# Altium schematic sheet AnalogSensorBoard.SchDoc
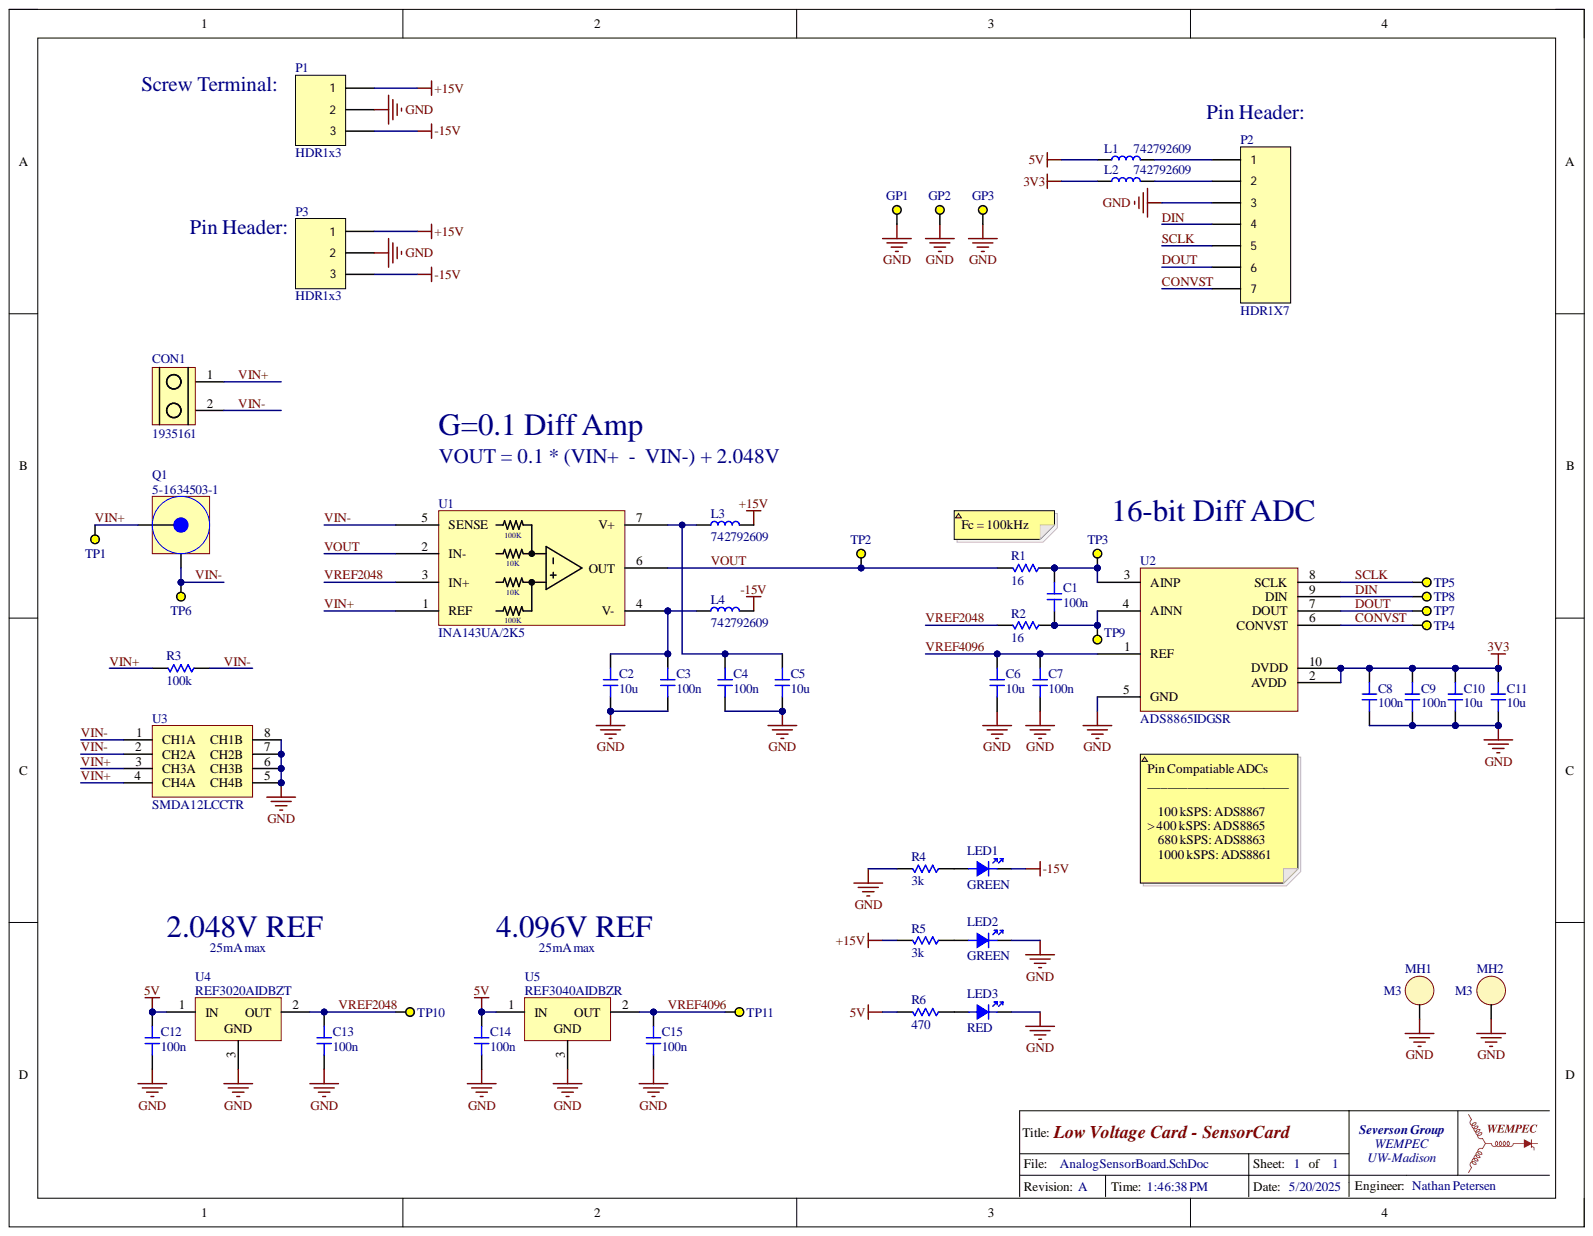
[diagram p0: AnalogSensorBoard.SchDoc]

## Parts
C1  value 100n  [0603-CAP]  (hidden comment: Capacitor)
C2  value 10u  [0603-CAP]  (hidden comment: Capacitor)
C3  value 100n  [0603-CAP]  (hidden comment: Capacitor)
C4  value 100n  [0603-CAP]  (hidden comment: Capacitor)
C5  value 10u  [0603-CAP]  (hidden comment: Capacitor)
C6  value 10u  [0603-CAP]  (hidden comment: Capacitor)
C7  value 100n  [0603-CAP]  (hidden comment: Capacitor)
C8  value 100n  [0603-CAP]  (hidden comment: Capacitor)
C9  value 100n  [0603-CAP]  (hidden comment: Capacitor)
C10  value 10u  [0603-CAP]  (hidden comment: Capacitor)
C11  value 10u  [0603-CAP]  (hidden comment: Capacitor)
C12  value 100n  [0603-CAP]  (hidden comment: Capacitor)
C13  value 100n  [0603-CAP]  (hidden comment: Capacitor)
C14  value 100n  [0603-CAP]  (hidden comment: Capacitor)
C15  value 100n  [0603-CAP]  (hidden comment: Capacitor)
CON1  1935161  [1935161]
GP1  RCT-0C  [RCT-0C]  (value not drawn)
GP2  RCT-0C  [RCT-0C]  (value not drawn)
GP3  RCT-0C  [RCT-0C]  (value not drawn)
L1  742792609  [INDC1608X10N]
L2  742792609  [INDC1608X10N]
L3  742792609  [INDC1608X10N]
L4  742792609  [INDC1608X10N]
LED1  LED  value GREEN  [0603-LED]
LED2  LED  value GREEN  [0603-LED]
LED3  LED  value RED  [0603-LED]
MH1  M3  [MOUNTING HOLE - M3]  (no BOM)
MH2  M3  [MOUNTING HOLE - M3]  (no BOM)
P1  HDR1x3  [Term Block - 3 pos]
P2  HDR1X7  [HDR1x7]
P3  HDR1x3  [HDR1x3]
Q1  5-1634503-1  [5-1634503-1]
R1  value 16  [0603-RES]  (hidden comment: Resistor)
R2  value 16  [0603-RES]  (hidden comment: Resistor)
R3  value 100k  [0603-RES]  (hidden comment: Resistor)
R4  value 3k  [0603-RES]  (hidden comment: Resistor)
R5  value 3k  [0603-RES]  (hidden comment: Resistor)
R6  value 470  [0603-RES]  (hidden comment: Resistor)
TP1  RCT-0C  [RCT-0C]  (value not drawn)
TP2  RCT-0C  [RCT-0C]  (value not drawn)
TP3  RCT-0C  [RCT-0C]  (value not drawn)
TP4  RCT-0C  [RCT-0C]  (value not drawn)
TP5  RCT-0C  [RCT-0C]  (value not drawn)
TP6  RCT-0C  [RCT-0C]  (value not drawn)
TP7  RCT-0C  [RCT-0C]  (value not drawn)
TP8  RCT-0C  [RCT-0C]  (value not drawn)
TP9  RCT-0C  [RCT-0C]  (value not drawn)
TP10  RCT-0C  [RCT-0C]  (value not drawn)
TP11  RCT-0C  [RCT-0C]  (value not drawn)
U1  INA143UA/2K5  [SOIC127P600X175-8N]
U2  ADS8865IDGSR  [TSSOP50P490X110-10N]
U3  SMDA12LCCTR  [SOIC127P600X175-8N]
U4  REF3020AIDBZT  [SOT23-3]
U5  REF3040AIDBZR  [SOT23-3]

## Nets
3V3: C8.1 C9.1 C10.1 C11.1 L2.1 U2.2(AVDD) U2.10(DVDD)
5V: C12.1 C14.1 L1.1 R6.2 U4.1 U5.1
+15V: L3.2 P1.1 P3.1 R5.2
-15V: L4.2 LED1.C P1.3 P3.3
GND: C2.2 C3.2 C4.2 C5.2 C6.2 C7.2 C8.2 C9.2 C10.2 C11.2 C12.2 C13.2 C14.2 C15.2 GP1.1 GP2.1 GP3.1 LED2.C LED3.C MH1.1 MH2.1 P1.2 P2.3 P3.2 R4.2 U2.5(GND) U3.5(CH4B) U3.6(CH3B) U3.7(CH2B) U3.8(CH1B) U4.3 U5.3
CONVST: P2.7 TP4.1 U2.6(CONVST)
DIN: P2.4 TP8.1 U2.9(DIN)
DOUT: P2.6 TP7.1 U2.7(DOUT)
SCLK: P2.5 TP5.1 U2.8(SCLK)
VIN+: CON1.1 Q1.1 R3.2 TP1.1 U1.1(REF) U3.3(CH3A) U3.4(CH4A)
VIN-: CON1.2 Q1.2 R3.1 TP6.1 U1.5(SENSE) U3.1(CH1A) U3.2(CH2A)
VOUT: R1.2 TP2.1 U1.2(IN-) U1.6(OUT)
VREF2048: C13.1 R2.2 TP10.1 U1.3(IN+) U4.2
VREF4096: C6.1 C7.1 C15.1 TP11.1 U2.1(REF) U5.2
NetC1_1: C1.1 R1.1 TP3.1 U2.3(AINP)
NetC1_2: C1.2 R2.1 TP9.1 U2.4(AINN)
NetC2_1: C2.1 C3.1 L4.1 U1.4(V-)
NetC4_1: C4.1 C5.1 L3.1 U1.7(V+)
NetL1_2: L1.2 P2.1
NetL2_2: L2.2 P2.2
NetLED1_A: LED1.A R4.1
NetLED2_A: LED2.A R5.1
NetLED3_A: LED3.A R6.1

## Library data of the parts
C1 C3 C4 C7-C9 C12-C15 = Capacitor: CAP CER 0.1UF 25V X7R 0603; Component Kind: Standard; Library Name: Schlib.SchLib; Simulation: CAP; Supplier 1: Digi-Key; Supplier Part Number 1: 311-1341-1-ND
C2 C5 C6 C10 C11 = Capacitor: CAP CER 10UF 25V X5R 0603; Component Kind: Standard; Library Name: Schlib.SchLib; Simulation: CAP; Supplier 1: Digi-Key; Supplier Part Number 1: 490-12737-1-ND
CON1 = ScrewTerminal_1x2: TERM BLK 2POS SIDE ENTRY 5MM PCB; Component Kind: Standard; Supplier 1: Digi-Key; Supplier Part Number 1: 277-1667-ND; Library Name: AMDC.SchLib
GP1-GP3 TP1-TP11 = RCT-0C: PC TEST POINT NATURAL; Component Kind: Standard; Library Name: AnalogCard.SchLib; Supplier 1: Digi-Key; Supplier Part Number 1: A106144CT-ND
L1-L4 = 742792609: FERRITE BEAD 30 OHM 0603 1LN; Value: 30R; Component Kind: Standard; Library Name: AnalogCard.SchLib; Supplier 1: Digi-Key; Supplier Part Number 1: 732-1591-1-ND
LED1 LED2 = LED: LED GREEN CLEAR 0603 SMD; Component Kind: Standard; Library Name: Schlib.SchLib; Supplier 1: Digi-Key; Supplier Part Number 1: 732-4980-1-ND
LED3 = LED: LED RED CLEAR 0603 SMD; Component Kind: Standard; Library Name: Schlib.SchLib; Supplier 1: Digi-Key; Supplier Part Number 1: 732-4978-1-ND
MH1 MH2 = MOUNT_HOLE: MOUNTING HOLE; Library Name: SensorCard.SchLib
P1 = HDR1x3: TERM BLK 3P SIDE ENT 2.54MM PCB; Manufacturer: TE Connectivity; Manufacturer Part Number: 282834-3; Approvals: UL; Closed End: No (Without); Connector Type: Receptacle; Current: 10A; Max Supply Voltage (AC): 150V; Number of Circuits: 3; Number of Levels: 1; Plating: Brass; Screwless Terminal Block: Yes (With); Tail Length: 3.4mm; Thread Size: M1.6; Wire Gauge (Max): 30AWG; Color: Green; Component Kind: Standard; ComponentLink1Description: https://datasheet.ciiva.com/11757/0900766b80f924bc-11757569.pdf?src-supplier=RSComponents; ComponentLink1URL: https://datasheet.ciiva.com/11757/0900766b80f924bc-11757569.pdf?src-supplier=RSComponents; ComponentLink2Description: https://datasheet.ciiva.com/15169/getdatasheetpartid-155865-15169559.pdf?src-supplier=Verical; ComponentLink2URL: https://datasheet.ciiva.com/15169/getdatasheetpartid-155865-15169559.pdf?src-supplier=Verical; ComponentLink3Description: https://datasheet.ciiva.com/30217/eng-cs-1308389-eurostyle-terminal-blocks-0607-30217495.pdf?src-supplier=Onlinecomponents.com; ComponentLink3URL: https://datasheet.ciiva.com/30217/eng-cs-1308389-eurostyle-terminal-blocks-0607-30217495.pdf?src-supplier=Onlinecomponents.com; ComponentLink4Description: https://datasheet.ciiva.com/823/eng-cd-282834-c1-823464.pdf?src-supplier=Digi-Key; ComponentLink4URL: https://datasheet.ciiva.com/823/eng-cd-282834-c1-823464.pdf?src-supplier=Digi-Key; ComponentLink5Description: https://datasheet.ciiva.com/14610/70087777-14610266.pdf?src-supplier=Allied+Electronics; ComponentLink5URL: https://datasheet.ciiva.com/14610/70087777-14610266.pdf?src-supplier=Allied+Electronics; ComponentLink6Description: https://datasheet.ciiva.com/3141/eng-cs-1308389-eurostyle-terminal-blocks-0607-3141116.pdf?src-supplier=Avnet; ComponentLink6URL: https://datasheet.ciiva.com/3141/eng-cs-1308389-eurostyle-terminal-blocks-0607-3141116.pdf?src-supplier=Avnet; ComponentLink7Description: https://datasheet.ciiva.com/16469/eng-cs-1308389-eurostyle-terminal-blocks-0607-16469502.pdf?src-supplier=Avnet+Asia; ComponentLink7URL: https://datasheet.ciiva.com/16469/eng-cs-1308389-eurostyle-terminal-blocks-0607-16469502.pdf?src-supplier=Avnet+Asia; ComponentLink8Description: https://datasheet.ciiva.com/7978/583107-7978559.pdf?src-supplier=Element14; ComponentLink8URL: https://datasheet.ciiva.com/7978/583107-7978559.pdf?src-supplier=Element14; Contact Material: Brass; Contact Plating: Tin; Current Rating: 10A; Depth: 6.5mm; ELV: Compliant; Flammability Rating: UL94 V-0; Housing Color: Green; Housing Material: Polyamide; Interlock: No (Without); Lead Free: Lead Free; Length: 8.08mm; Library Name: SensorCard.SchLib; Max Current Rating: 10A; Max Operating Temperature: 105°C; Max Voltage Rating (AC): 150V; Min Operating Temperature: -40°C; Mount: Through Hole; Number of Contacts: 3; Number of Poles: 3; Number of Positions: 3; Number of Positions Per Level: 3; Number of Rows: 1; Orientation: Straight; Package Quantity: 200; Packaging: Bulk; Pitch: 2.54mm; Pricing 1: 1=2.01000, 10=1.52300, 25=1.41160, 50=1.26920, 100=1.23820, 250=1.08340, 500=0.99052, 1000=0.89767, 5000=0.78933 (USD); Radiation Hardening: No; REACH SVHC: Unknown; RoHS: Yes; Screw Flange: No (Without); Side Stackable: Yes (With); Stock 1: 4635; Supplier 1: Digi-Key; Supplier Part Number 1: A98334-ND; Termination: Solder; Voltage: 150V; Voltage Rating: 150V; Width: 10mm; Wire Gauge (Min): 30AWG; Wire/Cable Gauge: 30AWG
P2 = HDR1X7: CONN RCPT 7POS 0.1 GOLD PCB; Manufacturer: TE Connectivity; Manufacturer Part Number: 5-534237-5; Approvals: CSA; Boss: No (Without); Connector Type: Receptacle; Contact Current Rating: 2A; Contact Post Length: 2.92mm; Contact Resistance: 12MR; High Temperature Compatible: No; Insulation Resistance: 5GR; Number of Contacts: 7; PCB Mount Retention: Without; PCB Mounting Orientation: Vertical; PCB Thickness: 2.4mm; Pitch: 2.54mm; Row Spacing: 0.1inch; Stackable: Yes; Voltage Rating: 750V; Color: Black; Component Kind: Standard; ComponentLink1Description: https://datasheet.ciiva.com/16318/eng-cs-1307819-section-5-0908-16318099.pdf?src-supplier=Future+Electronics; ComponentLink1URL: https://datasheet.ciiva.com/16318/eng-cs-1307819-section-5-0908-16318099.pdf?src-supplier=Future+Electronics; ComponentLink2Description: https://datasheet.ciiva.com/15175/getdatasheetpartid-36747-15175462.pdf?src-supplier=Verical; ComponentLink2URL: https://datasheet.ciiva.com/15175/getdatasheetpartid-36747-15175462.pdf?src-supplier=Verical; ComponentLink3Description: https://datasheet.ciiva.com/746/eng-cd-534237-r5-746279.pdf?src-supplier=Digi-Key; ComponentLink3URL: https://datasheet.ciiva.com/746/eng-cd-534237-r5-746279.pdf?src-supplier=Digi-Key; ComponentLink4Description: https://datasheet.ciiva.com/9479/eng-ds-1307819-ampmodu-0811-9479736.pdf?src-supplier=Avnet; ComponentLink4URL: https://datasheet.ciiva.com/9479/eng-ds-1307819-ampmodu-0811-9479736.pdf?src-supplier=Avnet; ComponentLink5Description: https://datasheet.ciiva.com/30056/eng-ds-1307819-ampmodu-0811-30056440.pdf?src-supplier=Onlinecomponents.com; ComponentLink5URL: https://datasheet.ciiva.com/30056/eng-ds-1307819-ampmodu-0811-30056440.pdf?src-supplier=Onlinecomponents.com; Contact Material: Bronze; Contact Plating: Gold; Contact Shape: Square; Current Rating: 2A; Depth: 3.56mm; ELV: Compliant; Flammability Rating: UL94 V-0; Gender: Receptacle; Height: 3.56mm; Housing Color: Black; Housing Material: Polyester; Lead Free: Lead Free; Length: 17.78mm; Library Name: SensorCard.SchLib; Max Operating Temperature: 105°C; Min Operating Temperature: -65°C; Mount: Through Hole; Number of Positions: 7; Number of Rows: 1; Orientation: Straight; Package Quantity: 32; Packaging: Rail/Tube; Pricing 1: 1=2.35000, 32=2.00094, 128=1.82703, 512=1.52250, 1024=1.30500, 5024=1.15710 (USD); Radiation Hardening: No; REACH SVHC: Unknown; RoHS: Yes; Sealable: No (Without); Stock 1: 2592; Supplier 1: Digi-Key; Supplier Part Number 1: A32908-ND; Tail Length: 2.92mm; Termination: Solder
P3 = HDR1x3: CONN RCPT 3POS 0.1 GOLD PCB; Manufacturer: TE Connectivity; Manufacturer Part Number: 215297-3; Connector Type: Receptacle; Contact Current Rating: 3A; Contact Post Length: 3.1mm; Contact Resistance: 12mR; Number of Contacts: 3; PCB Mount Retention: With; PCB Mounting Orientation: Vertical; PCB Thickness: 1.6mm; Pitch: 2.54mm; Sealable: No (Without); Stackable: Yes; Color: Green; Component Kind: Standard; ComponentLink1Description: https://datasheet.ciiva.com/32373/2152973-32373113.pdf?src-supplier=Onlinecomponents.com; ComponentLink1URL: https://datasheet.ciiva.com/32373/2152973-32373113.pdf?src-supplier=Onlinecomponents.com; ComponentLink2Description: https://datasheet.ciiva.com/11748/0900766b805762f6-11748764.pdf?src-supplier=RSComponents; ComponentLink2URL: https://datasheet.ciiva.com/11748/0900766b805762f6-11748764.pdf?src-supplier=RSComponents; ComponentLink3Description: https://datasheet.ciiva.com/8003/525120-8003961.pdf?src-supplier=Element14; ComponentLink3URL: https://datasheet.ciiva.com/8003/525120-8003961.pdf?src-supplier=Element14; ComponentLink4Description: https://datasheet.ciiva.com/6857/eng-cd-215297-d-basefilename-6857450.pdf?src-supplier=Digi-Key; ComponentLink4URL: https://datasheet.ciiva.com/6857/eng-cd-215297-d-basefilename-6857450.pdf?src-supplier=Digi-Key; ComponentLink5Description: https://datasheet.ciiva.com/1439/1651969-1439469.pdf?src-supplier=Farnell; ComponentLink5URL: https://datasheet.ciiva.com/1439/1651969-1439469.pdf?src-supplier=Farnell; ComponentLink6Description: https://datasheet.ciiva.com/14120/215297serie-eng-tds-14120829.pdf?src-supplier=Distrelec; ComponentLink6URL: https://datasheet.ciiva.com/14120/215297serie-eng-tds-14120829.pdf?src-supplier=Distrelec; Contact Gender: Female; Contact Material: Bronze; Contact Plating: Gold; Contact Shape: Square; Current Rating: 3A; Depth: 2.52mm; ELV: Compliant; Flammability Rating: UL94 V-0; Gender: Receptacle; Height: 7mm; Housing Color: Black; Housing Material: Polyester; Length: 7.62mm; Library Name: SensorCard.SchLib; Max Operating Temperature: 105°C; Min Operating Temperature: -55°C; Mount: Through Hole; Number of Positions: 3; Number of Rows: 1; Orientation: Straight; Package Quantity: 240; Packaging: Tray; Pricing 1: 1=0.81000, 10=0.71400, 100=0.61600, 500=0.50400, 1000=0.44800, 5000=0.39200, 10000=0.37240 (USD); RoHS: Yes; Stock 1: 7420; Supplier 1: Digi-Key; Supplier Part Number 1: A32904-ND; Tail Length: 3.1mm; Termination: Solder
Q1 = 5-1634503-1: CONN BNC JACK STR 50 OHM PCB; Component Kind: Standard; Library Name: Schlib.SchLib; Supplier 1: Digi-Key; Supplier Part Number 1: A97581-ND
R1 R2 = Resistor: RES 16 OHM 1% 1/10W 0603; Component Kind: Standard; Simulation: RES; Supplier 1: Digi-Key; Supplier Part Number 1: 311-16.0HRCT-ND
R3 = Resistor: RES 100K OHM 1% 1/10W 0603; Manufacturer 1: YAGEO; Manufacturer Part Number 1: RC0603FR-07100KL; Component Kind: Standard; Simulation: RES; Supplier 1: Digi-Key; Supplier Part Number 1: 311-100KHRCT-ND
R4 R5 = Resistor: RES 3K OHM 1% 1/10W 0603; Component Kind: Standard; Simulation: RES; Supplier 1: Digi-Key; Supplier Part Number 1: 311-3.00KHRCT-ND
R6 = Resistor: RES 470 OHM 5% 1/10W 0603; Manufacturer 1: YAGEO; Manufacturer Part Number 1: RC0603JR-07470RL; Component Kind: Standard; Simulation: RES; Supplier 1: Digi-Key; Supplier Part Number 1: 311-470GRCT-ND
U1 = INA143UA/2K5: IC OPAMP DIFF 1 CIRCUIT 8SOIC; Component Kind: Standard; Library Name: AnalogCard.SchLib; Supplier 1: Digi-Key; Supplier Part Number 1: 296-46094-1-ND
U2 = ADS8865IDGSR: IC ADC 16BIT SAR 10VSSOP; Component Kind: Standard; Library Name: AnalogCard.SchLib; Supplier 1: Digi-Key; Supplier Part Number 1: 296-39883-1-ND
U3 = SMDA12CTR: TVS DIODE 12V 19V 8SO; Manufacturer 1: SMC Diode Solutions; Component Kind: Standard; Manufacturer Part Number 1: SMDA12LCC; Supplier 1: Digi-Key; Supplier Part Number 1: 1655-2009-1-ND; Library Name: AMDC.SchLib
U4 U5 = REF3020AIDBZT: Series Voltage Reference IC ±0.2% 25mA SOT-23-3; Component Kind: Standard; Supplier 1: Digi-Key; Library Name: AMDC.SchLib
  U4: Supplier Part Number 1: REF3020AIDBZTCT-ND
  U5: Supplier Part Number 1: 296-35920-1-ND
